# Altium schematic sheet main.SchDoc
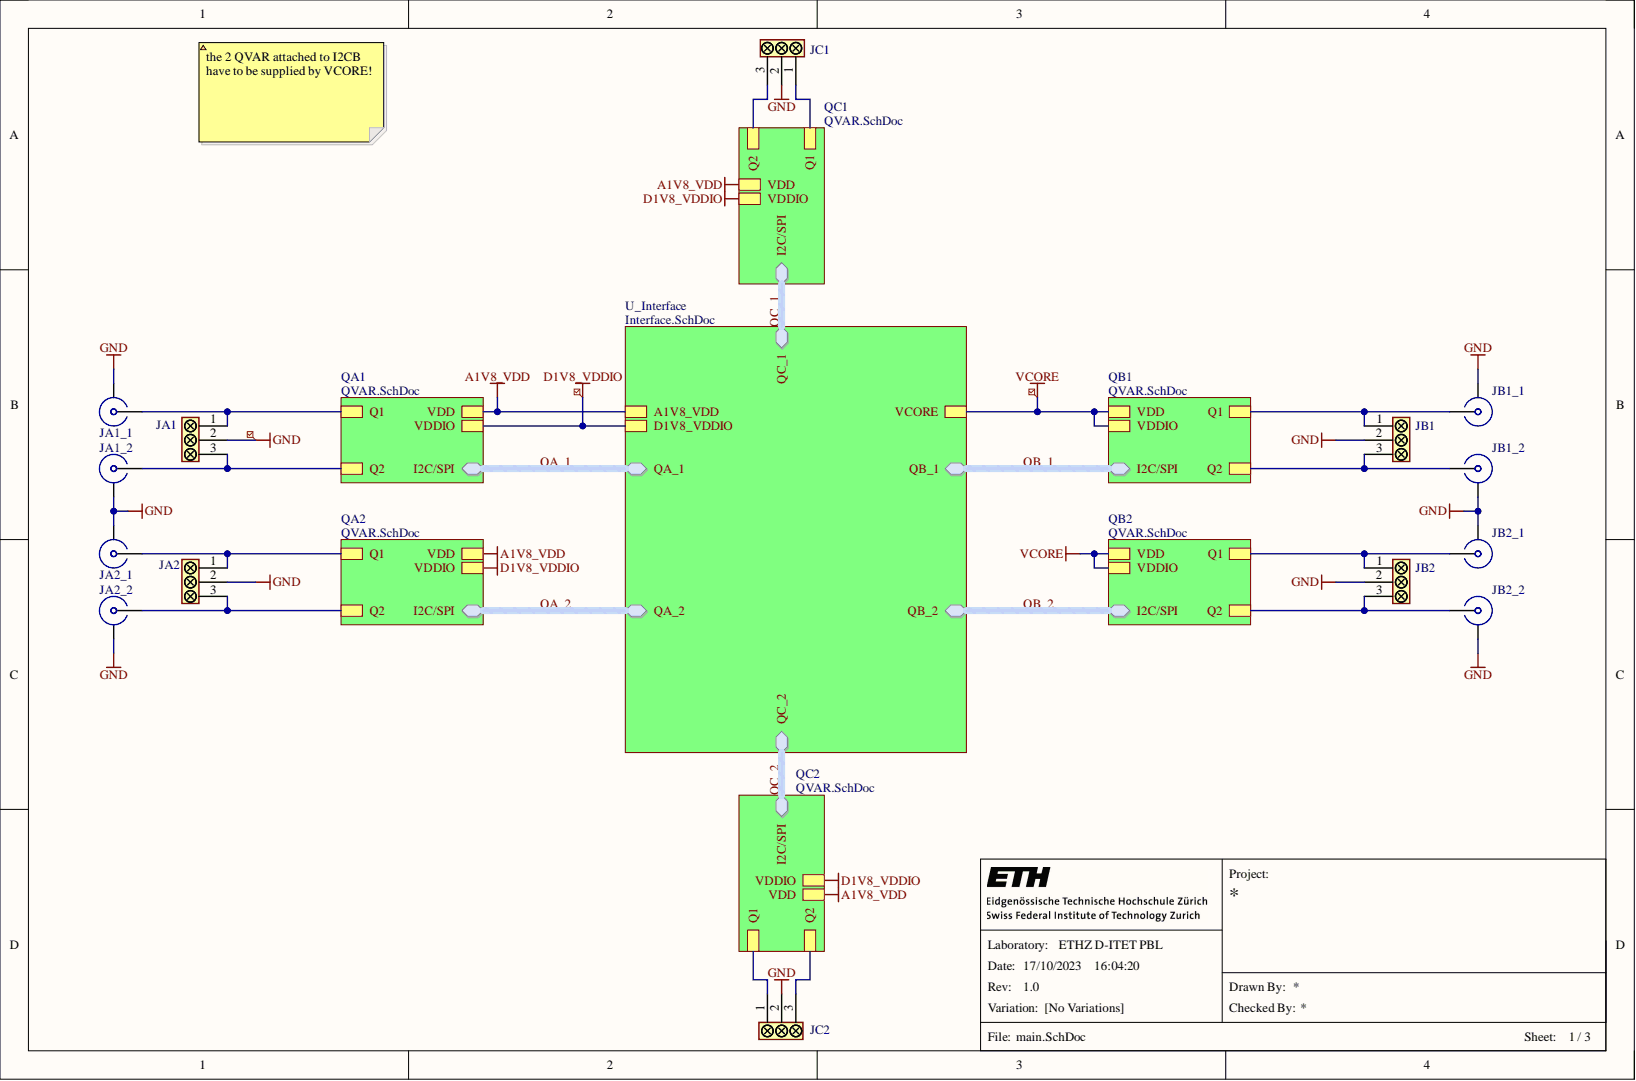
[diagram p0: main.SchDoc]

## Parts
JA1  FTS-103-01-L-S  [SAMTEC-FTS-103-01-X-S]  (value not drawn)
JA1_1  CONMHF1-SMD-G-T  [C-CONMHF1-SMD-G-T]  (value not drawn)
JA1_2  CONMHF1-SMD-G-T  [C-CONMHF1-SMD-G-T]  (value not drawn)
JA2  FTS-103-01-L-S  [SAMTEC-FTS-103-01-X-S]  (value not drawn)
JA2_1  CONMHF1-SMD-G-T  [C-CONMHF1-SMD-G-T]  (value not drawn)
JA2_2  CONMHF1-SMD-G-T  [C-CONMHF1-SMD-G-T]  (value not drawn)
JB1  FTS-103-01-L-S  [SAMTEC-FTS-103-01-X-S]  (value not drawn)
JB1_1  CONMHF1-SMD-G-T  [C-CONMHF1-SMD-G-T]  (value not drawn)
JB1_2  CONMHF1-SMD-G-T  [C-CONMHF1-SMD-G-T]  (value not drawn)
JB2  FTS-103-01-L-S  [SAMTEC-FTS-103-01-X-S]  (value not drawn)
JB2_1  CONMHF1-SMD-G-T  [C-CONMHF1-SMD-G-T]  (value not drawn)
JB2_2  CONMHF1-SMD-G-T  [C-CONMHF1-SMD-G-T]  (value not drawn)
JC1  FTS-103-01-L-S  [SAMTEC-FTS-103-01-X-S]  (value not drawn)
JC2  FTS-103-01-L-S  [SAMTEC-FTS-103-01-X-S]  (value not drawn)

## Nets
GND: JA1.2 JA1_1.G JA1_2.G JA2.2 JA2_1.G JA2_2.G JB1.2 JB1_1.G JB1_2.G JB2.2 JB2_1.G JB2_2.G JC1.2 JC2.2
NetJA1_1: JA1.1 JA1_1.S
NetJA1_2_S: JA1.3 JA1_2.S
NetJA2_1: JA2.1 JA2_1.S
NetJA2_2_S: JA2.3 JA2_2.S
NetJB1_1: JB1.1 JB1_1.S
NetJB1_2_S: JB1.3 JB1_2.S
NetJB2_1: JB2.1 JB2_1.S
NetJB2_2_S: JB2.3 JB2_2.S
NetJC1_1: JC1.1
NetJC1_3: JC1.3
NetJC2_1: JC2.1
NetJC2_3: JC2.3
A1V8_VDD:
D1V8_VDDIO:
QA_1.I2C/CS:
QA_1.INT1:
QA_1.SA0/MISO:
QA_1.SCL/SCK:
QA_1.SDA/MOSI:
QA_2.I2C/CS:
QA_2.INT1:
QA_2.SA0/MISO:
QA_2.SCL/SCK:
QA_2.SDA/MOSI:
QB_1.I2C/CS:
QB_1.INT1:
QB_1.SA0/MISO:
QB_1.SCL/SCK:
QB_1.SDA/MOSI:
QB_2.I2C/CS:
QB_2.INT1:
QB_2.SA0/MISO:
QB_2.SCL/SCK:
QB_2.SDA/MOSI:
QC_1.I2C/CS:
QC_1.INT1:
QC_1.SA0/MISO:
QC_1.SCL/SCK:
QC_1.SDA/MOSI:
QC_2.I2C/CS:
QC_2.INT1:
QC_2.SA0/MISO:
QC_2.SCL/SCK:
QC_2.SDA/MOSI:
VCORE:

## Library data of the parts
JA1 JA2 JB1 JB2 JC1 JC2 = FTS-103-01-L-S: Pinheader 3 pin, 1.27mm; Manufacturer: Samtec; Manufacturer Series: FTS; Additional Feature: Low Profile; Connector Type: BOARD CONNECTOR; DIN Conformance: No; Number of Contacts: 3; Number of Rows Loaded: 1; Option: General Purpose; Category: Connectors; Component Kind: Standard; ComponentLink1Description: https://datasheet.ciiva.com:443/pdfs/VipMasterIC/IC/SAMI/SAMI-S-A0001504673/SAMI-S-A0001504673-1.pdf?src-supplier=IHS+Markit; ComponentLink1URL: https://datasheet.ciiva.com:443/pdfs/VipMasterIC/IC/SAMI/SAMI-S-A0001504673/SAMI-S-A0001504673-1.pdf?src-supplier=IHS+Markit; ComponentLink2Description: http://suddendocs.samtec.com/prints/fts-1xx-xx-x-sx-mkt.pdf?src-supplier=Digi-Key; ComponentLink2URL: http://suddendocs.samtec.com/prints/fts-1xx-xx-x-sx-mkt.pdf?src-supplier=Digi-Key; ComponentLink3Description: http://suddendocs.samtec.com/prints/fts-1xx-xx-xx-xx-xx-mkt.pdf?src-supplier=Digi-Key; ComponentLink3URL: http://suddendocs.samtec.com/prints/fts-1xx-xx-xx-xx-xx-mkt.pdf?src-supplier=Digi-Key; ComponentLink4Description: ftp://ftp.samtec.com/webfiles/PDF/FTS.PDF?src-supplier=Avnet; ComponentLink4URL: ftp://ftp.samtec.com/webfiles/PDF/FTS.PDF?src-supplier=Avnet; Contact Finish - Mating: GOLD (10) OVER NICKEL (50); Contact Finish - Termination: Matte Tin (Sn) - with Nickel (Ni) barrier; Contact Gender: Male; Contact Material: Bronze; Filter Feature: No; Flammability Rating: UL94 V-0; IEC Conformance: No; JESD-609 Code: e3; Manufacturer Part Number: FTS-103-01-L-S; MIL Conformance: No; Mixed Contacts: No; Mounting Style: STRAIGHT; Mounting Technology: Board; Pricing 1: 1=1.334, 5=1.281, 10=1.208 (CHF); Reach SVHC Compliant: Yes; RoHS: Yes; RoHS Compliant: Yes; Stock 1: 187; Supplier 1: Rs; Terminal Pitch: 1.27mm; Termination: Solder; Library Name: VC_SchematicLib.SchLib
JA1_1 JA1_2 JA2_1 JA2_2 JB1_1 JB1_2 JB2_1 JB2_2 = CONMHF1-SMD-G-T: Conn Umc Jack Str SMD; Manufacturer: Linx; Category: RF / Coaxial Connectors; Component Kind: Standard; Manufacturer Part Number: CONMHF1-SMD-G-T; Pricing 1: 2=0.54, 20=0.39, 100=0.33, 500=0.27 (EUR); RoHS: No; Stock 1: 263; Supplier 1: TME; Library Name: VC_SchematicLib.SchLib
